# Altium schematic sheet 2_PowerSupply.SchDoc
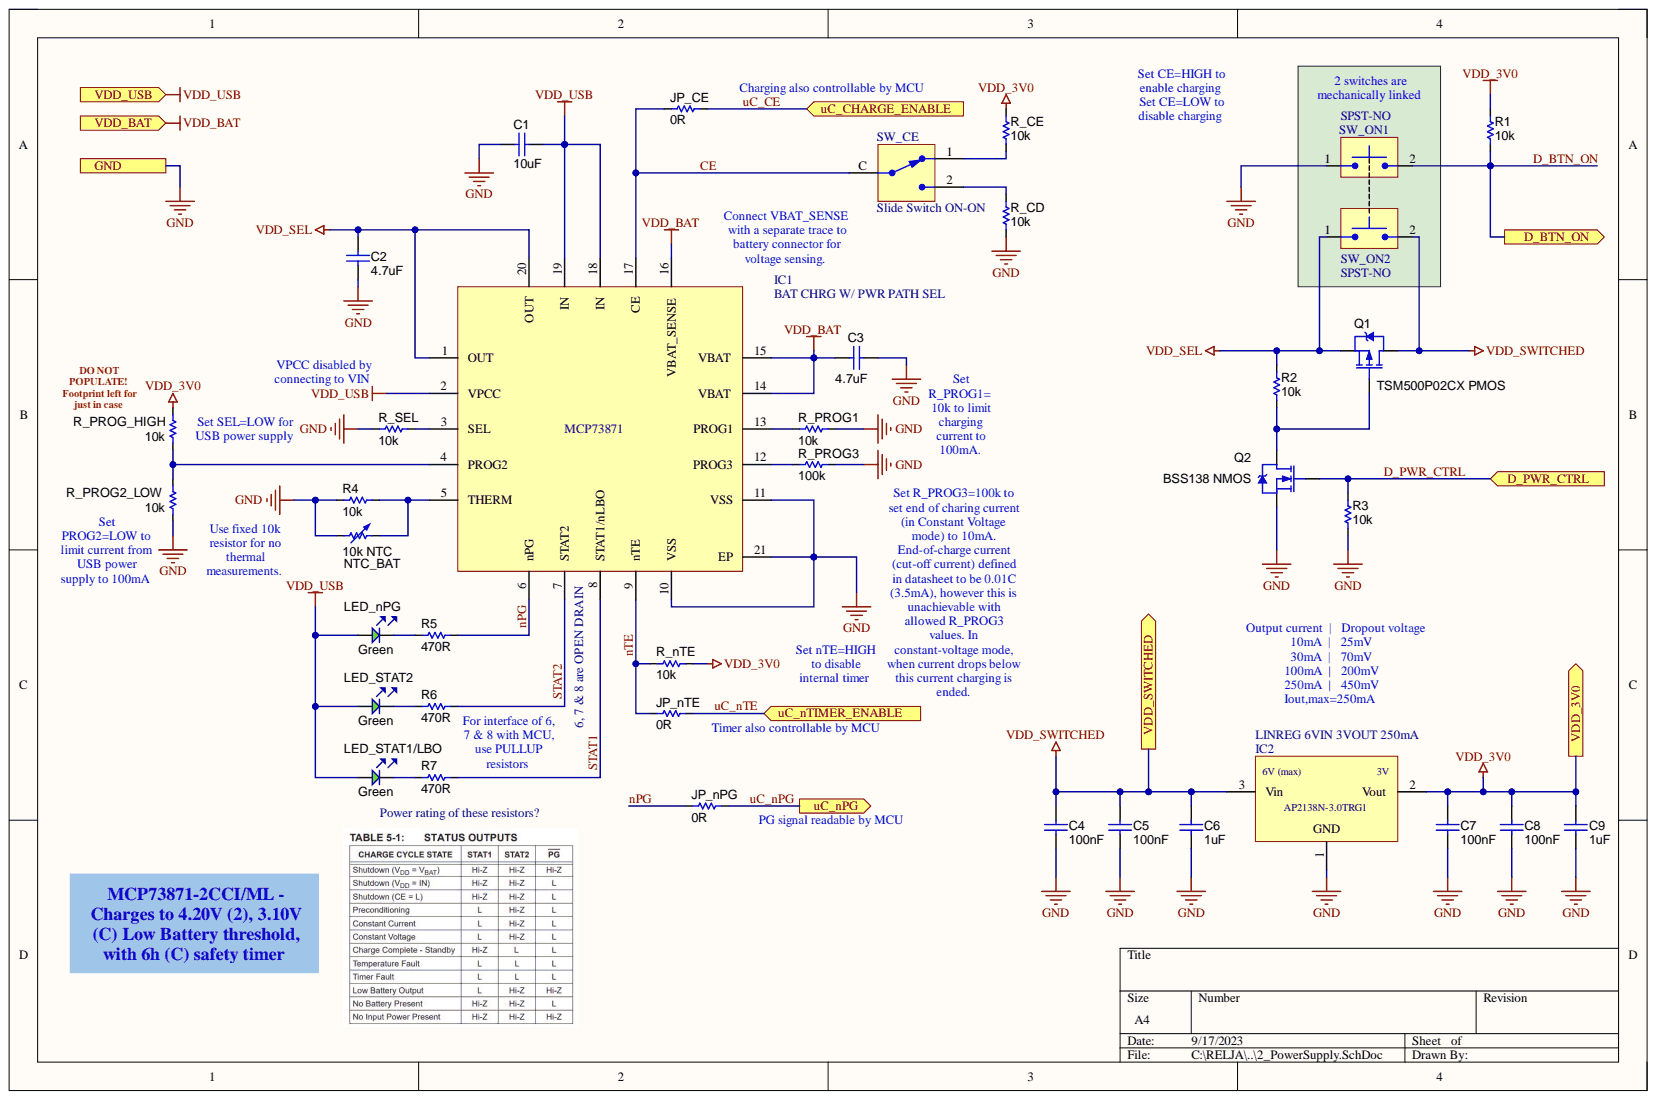
[diagram p0: 2_PowerSupply.SchDoc]

## Parts
C1  10uF  [CAP_0603]
C2  4.7uF  [CAP_0805]
C3  4.7uF  [CAP_0805]
C4  100nF  [CAP_0603]
C5  100nF  [CAP_0603]
C6  1uF  [CAP_0603]
C7  100nF  [CAP_0603]
C8  100nF  [CAP_0603]
C9  1uF  [CAP_0603]
IC1  BAT CHRG W/ PWR PATH SEL  [20-VFQFN EP (4mm x 4mm)]
IC2  LINREG 6VIN 3VOUT 250mA  Manufacturer Part Number: AP2138N-3.0TRG1  Supply Voltage: 6V (max)  [SOT-23-3]
JP_CE  0R  [RES_0603]
JP_nPG  0R  [RES_0603]
JP_nTE  0R  [RES_0603]
LED_nPG  Green  [LED_0603]
LED_STAT1/LBO  Green  [LED_0603]
LED_STAT2  Green  [LED_0603]
NTC_BAT  10k NTC  [RES VERT TH 5MM]
Q1  TSM500P02CX PMOS  Manufacturer Part Number: TSM500P02CX  [SOT-23-3]
Q2  BSS138 NMOS  [SOT-23-3]
R1  10k  [RES_0603]
R2  10k  [RES_0603]
R3  10k  [RES_0603]
R4  10k  [RES_0603]
R5  470R  [RES_0603]
R6  470R  [RES_0603]
R7  470R  [RES_0603]
R_CD  10k  [RES_0603]
R_CE  10k  [RES_0603]
R_nTE  10k  [RES_0603]
R_PROG1  10k  [RES_0603]
R_PROG2_LOW  10k  [RES_0603]
R_PROG3  100k  [RES_0603]
R_PROG_HIGH  10k  [RES_0603]
R_SEL  10k  [RES_0603]
SW_CE  Slide Switch ON-ON  [SWITCH SPDT 2.54MM R/A]
SW_ON1  SPST-NO  [SWITCH_TACTILE_SMD_6X3.5mm_2pin]
SW_ON2  SPST-NO  [SWITCH_TACTILE_SMD_6X3.5mm_2pin]

## Ports
D_BTN_ON, D_PWR_CTRL, GND, uC_CHARGE_ENABLE, uC_nPG, uC_nTIMER_ENABLE, VDD_3V0, VDD_BAT, VDD_SWITCHED, VDD_USB

## Nets
VDD_SEL: C2.1 IC1.1(OUT) IC1.20(OUT) Q1.2 R2.2 SW_ON2.1
CE: IC1.17(CE) JP_CE.1 SW_CE.C
D_BTN_ON: R1.1 SW_ON1.2 ; port D_BTN_ON
D_PWR_CTRL: Q2.1 R3.2 ; port D_PWR_CTRL
GND: C1.2 C2.2 C3.2 C4.2 C5.2 C6.2 C7.2 C8.2 C9.2 IC1.10(VSS) IC1.11(VSS) IC1.21(EP) IC2.1 NTC_BAT.1 Q2.2 R3.1 R4.1 R_CD.1 R_PROG1.1 R_PROG2_LOW.1 R_PROG3.2 R_SEL.1 SW_ON1.1 ; port GND
nPG: IC1.6(nPG) JP_nPG.2 R5.2
nTE: IC1.9(nTE) JP_nTE.2 R_nTE.1
STAT1: IC1.8(STAT1/nLBO) R7.2
STAT2: IC1.7(STAT2) R6.2
uC_CE: JP_CE.2 ; port uC_CHARGE_ENABLE
uC_nPG: JP_nPG.1 ; port uC_nPG
uC_nTE: JP_nTE.1 ; port uC_nTIMER_ENABLE
VDD_3V0: C7.1 C8.1 C9.1 IC2.2 R1.2 R_CE.1 R_nTE.2 R_PROG_HIGH.2 ; port VDD_3V0
VDD_BAT: C3.1 IC1.14(VBAT) IC1.15(VBAT) IC1.16(VBAT_SENSE) ; port VDD_BAT
VDD_SWITCHED: C4.1 C5.1 C6.1 IC2.3 Q1.3 SW_ON2.2 ; port VDD_SWITCHED
VDD_USB: C1.1 IC1.2(VPCC) IC1.18(IN) IC1.19(IN) LED_nPG.A LED_STAT1/LBO.A LED_STAT2.A ; port VDD_USB
NetIC1_3: IC1.3 R_SEL.2
NetIC1_4: IC1.4(PROG2) R_PROG2_LOW.2 R_PROG_HIGH.1
NetIC1_5: IC1.5(THERM) NTC_BAT.2 R4.2
NetIC1_12: IC1.12(PROG3) R_PROG3.1
NetIC1_13: IC1.13(PROG1) R_PROG1.2
NetLED_nPG_K: LED_nPG.K R5.1
NetLED_STAT1/LBO_K: LED_STAT1/LBO.K R7.1
NetLED_STAT2_K: LED_STAT2.K R6.1
NetQ1_1: Q1.1 Q2.3 R2.1
NetR_CD_2: R_CD.2 SW_CE.2
NetR_CE_2: R_CE.2 SW_CE.1

## Library data of the parts
C1 = CAP_10uF_16V_0603: Cermacic Capacitor 0603 16V 10uF; Capacitance: 10uF; Component Kind: Standard; Dielectric class: n/a; Manufacturer: n/a; Manufacturer Part Number: n/a; Package: 0603; Supplier: Kelco; Supplier Part Number: 20214; Tolerance: n/a; Voltage Rating: 16V; Library Name: Diplomski.IntLib
C2 C3 = CAP_4.7uF_25V_0805: Cermacic Capacitor 0805 25V 4.7uF; Capacitance: 4.7uF; Component Kind: Standard; Dielectric class: n/a; Manufacturer: n/a; Manufacturer Part Number: n/a; Package: 0805; Supplier: Kelco; Supplier Part Number: 20226; Tolerance: n/a; Voltage Rating: 25v; Library Name: Diplomski.IntLib
C4 C5 C7 C8 = CAP_100nF_50V_0603: Cermacic Capacitor 0603 50V 100nF; Dielectric class: X7R; Capacitance: 100nF; Component Kind: Standard; Manufacturer: n/a; Manufacturer Part Number: n/a; Package: 0603; Supplier: Kelco; Supplier Part Number: 11061; Tolerance: n/a; Voltage Rating: 50V; Library Name: Diplomski.IntLib
C6 C9 = CAP_1uF_16V_0603: Cermacic Capacitor 0603 16V 1uF; Dielectric class: Y5V; Capacitance: 1uF; Component Kind: Standard; Manufacturer: n/a; Manufacturer Part Number: n/a; Package: 0603; Supplier: Kelco; Supplier Part Number: 12930; Tolerance: n/a; Voltage Rating: 16V; Library Name: Diplomski.IntLib
IC1 = IC_BATCHRG_PWRPATH_MCP73871: Stand-Alone System Load Sharing and Li-Ion/Li-Polymer Battery Charge Management Controller  Battery charger with power path selection; Manufacturer: Microchip; Manufacturer Part Number: MCP73871-2CCI/ML; Quiescent Current: 180uA; Supply Voltage: 4.2V (battery), 6V (USB); Component Kind: Standard; Operating Temperature: -40°C ~ +85°C; Package: 20-VFQFN Exposed Pad; Supplier: Digikey; Supplier Part Number: MCP73871-2CCI/ML-ND; Value: BAT CHRG W/ PWR PATH SEL; Library Name: Diplomski.IntLib
IC2 = IC_LINREG_6V_3V_250mA_SOT23-3: IC Linear Regulator Fixed 3V 250mA 6Vinmax SOT-23-3; Manufacturer: Diodes Incorporated; Quiescent Current: 1uA; Component Kind: Standard; ComponentLink1Description: Datasheet; ComponentLink1URL: https://www.diodes.com/assets/Datasheets/AP2138-9.pdf; Operating Temperature: -40°C ~ 85°C; Package: SOT-23-3; Supplier: DigiKey; Supplier Part Number: AP2138N-3.0TRG1DICT-ND; Value: LINREG 6VIN 3VOUT 250mA; Library Name: Diplomski.IntLib
JP_CE JP_nPG JP_nTE = RES_0R_1%_0.1W_0603: Resistor SMD 0603 0R 0.1W 1%; Component Kind: Standard; Manufacturer: n/a; Manufacturer Part Number: n/a; Package: 0603; Power Rating: 0.1W; Resistance: 0R; Supplier: Kelco; Supplier Part Number: 13738; Tolerance: 1%; Library Name: Diplomski.IntLib
LED_nPG LED_STAT1/LBO LED_STAT2 = LED_Green_3V_20mA_0603: LED Diode SMD Green; Angle of Visibility: 120deg; Forward Current: 20mA; Forward Voltage: 3V; Intensity: 120mCd; Color: Green; Component Kind: Standard; Manufacturer: n/a; Manufacturer Part Number: n/a; Package: 0603; Supplier: Kelco; Supplier Part Number: 18013; Library Name: Diplomski.IntLib
NTC_BAT = THERM_NTC_10k_10%_0.25W_TH: Thermistor NTC though-hole 10k @ 25degC 1/4W 10%; Power Rating: 0.25W; Component Kind: Standard; ComponentLink1Description: Datasheet; ComponentLink1URL: https://www.tdk-electronics.tdk.com/inf/50/db/ntc/NTC_Leaded_disks_K164.pdf; Manufacturer: n/a; Manufacturer Part Number: n/a; Package: TH; Resistance: 10k NTC; Supplier: Kelco; Supplier Part Number: 4450; Tolerance: 10%; Library Name: Diplomski.IntLib
Q1 = MOSFET_P_20V_4.7A_TSM500P02CX_SOT-23: MOSFET P-channel SMD TSM500P02CX; Manufacturer: Taiwan Semiconductor; IDmax: 4.7A; IDmax (pulse): 18.8A; PDmax: 1.56W; RDSon: 57mOhm; Transconductance gfs: 7S; VDSmax: 20V; VGSmax: 10V; Vth: 0.6V; Component Kind: Standard; ComponentLink1Description: Datasheet; ComponentLink1URL: https://www.taiwansemi.com/assets/uploads/datasheet/TSM500P02CX_B1811.pdf; Package: SOT-23-3; Supplier: Kelco; Supplier Part Number: 18879; Value: TSM500P02CX PMOS; Library Name: Diplomski.IntLib
Q2 = MOSFET_N_60V_220mA_BSS138_SOT-23: MOSFET N-channel SMD BSS138 220mA 50V; Manufacturer: ONsemi; IDmax (pulse): 880mA; PDmax: 0.35W; RDSon: 1Ohm; Transconductance gfs: 0.5S; VDSmax: 60V; VGSmax: 20V; Vth: 1.3V; Component Kind: Standard; ComponentLink1Description: Datasheet; ComponentLink1URL: https://www.onsemi.com/pdf/datasheet/nds7002a-d.pdf; IDmax: 220mA; Manufacturer Part Number: BSS138; Package: SOT-23-3; Supplier: Kelco; Supplier Part Number: 19727; Value: BSS138 NMOS; Library Name: Diplomski.IntLib
R1-R4 R_CD R_CE R_nTE R_PROG1 R_PROG2_LOW R_PROG_HIGH R_SEL = RES_10k_1%_0.1W_0603: Resistor SMD 0603 10k 0.1W 1%; Component Kind: Standard; Manufacturer: n/a; Manufacturer Part Number: n/a; Package: 0603; Power Rating: 0.1W; Resistance: 10k; Supplier: Kelco; Supplier Part Number: 11702; Tolerance: 1%; Library Name: Diplomski.IntLib
R5-R7 = RES_470R_1%_0.1W_0603: Resistor SMD 0603 0R 0.1W 1%; Component Kind: Standard; Manufacturer: n/a; Manufacturer Part Number: n/a; Package: 0603; Power Rating: 0.1W; Resistance: 470R; Supplier: Kelco; Supplier Part Number: 8983; Tolerance: 1%; Library Name: Diplomski.IntLib
R_PROG3 = RES_100k_1%_0.1W_0603: Resistor SMD 0603 100k 0.1W 1%; Component Kind: Standard; Manufacturer: n/a; Manufacturer Part Number: n/a; Package: 0603; Power Rating: 0.1W; Resistance: 100k; Supplier: Kelco; Supplier Part Number: 11704; Tolerance: 1%; Library Name: Diplomski.IntLib
SW_CE = SWITCH_SLIDE_SPDT_ESP201_R/A_2.54mm: Slide switch 2.54mm pitch Single Pole Double Throw (ON-ON) ESP201 Right Angle; Manufacturer: ECE; Contact Configuration: SPDT; Rated Current: 500mA; Rated Voltage: 12VDC; Component Kind: Standard; Manufacturer Part Number: ESP201; Orientation: Right Angle; Pitch: 2.54mm; Supplier: Kelco; Supplier Part Number: 14323; Value: Slide Switch ON-ON; Library Name: Diplomski.IntLib
SW_ON1 SW_ON2 = SWITCH_SMD_6X3.5mm_2pin: SMD Tactile switch 2 pin 6x3.5mm SPST-NO 5mm height; Manufacturer: Diptronics; Manufacturer Part Number: DTSM-32N; Contact Resistance: 100mOhm; Rated Current: 50mA; Rated Voltage: 12V; Component Kind: Standard; ComponentLink1Description: Datasheet; ComponentLink1URL: https://eu.mouser.com/datasheet/2/910/444_DTS-3-1571561.pdf; Contact form: SPST-NO; Supplier: Kelco; Supplier Part Number: 15159; Library Name: Diplomski.IntLib
